# Altium schematic sheet RotaryEncoder.SchDoc
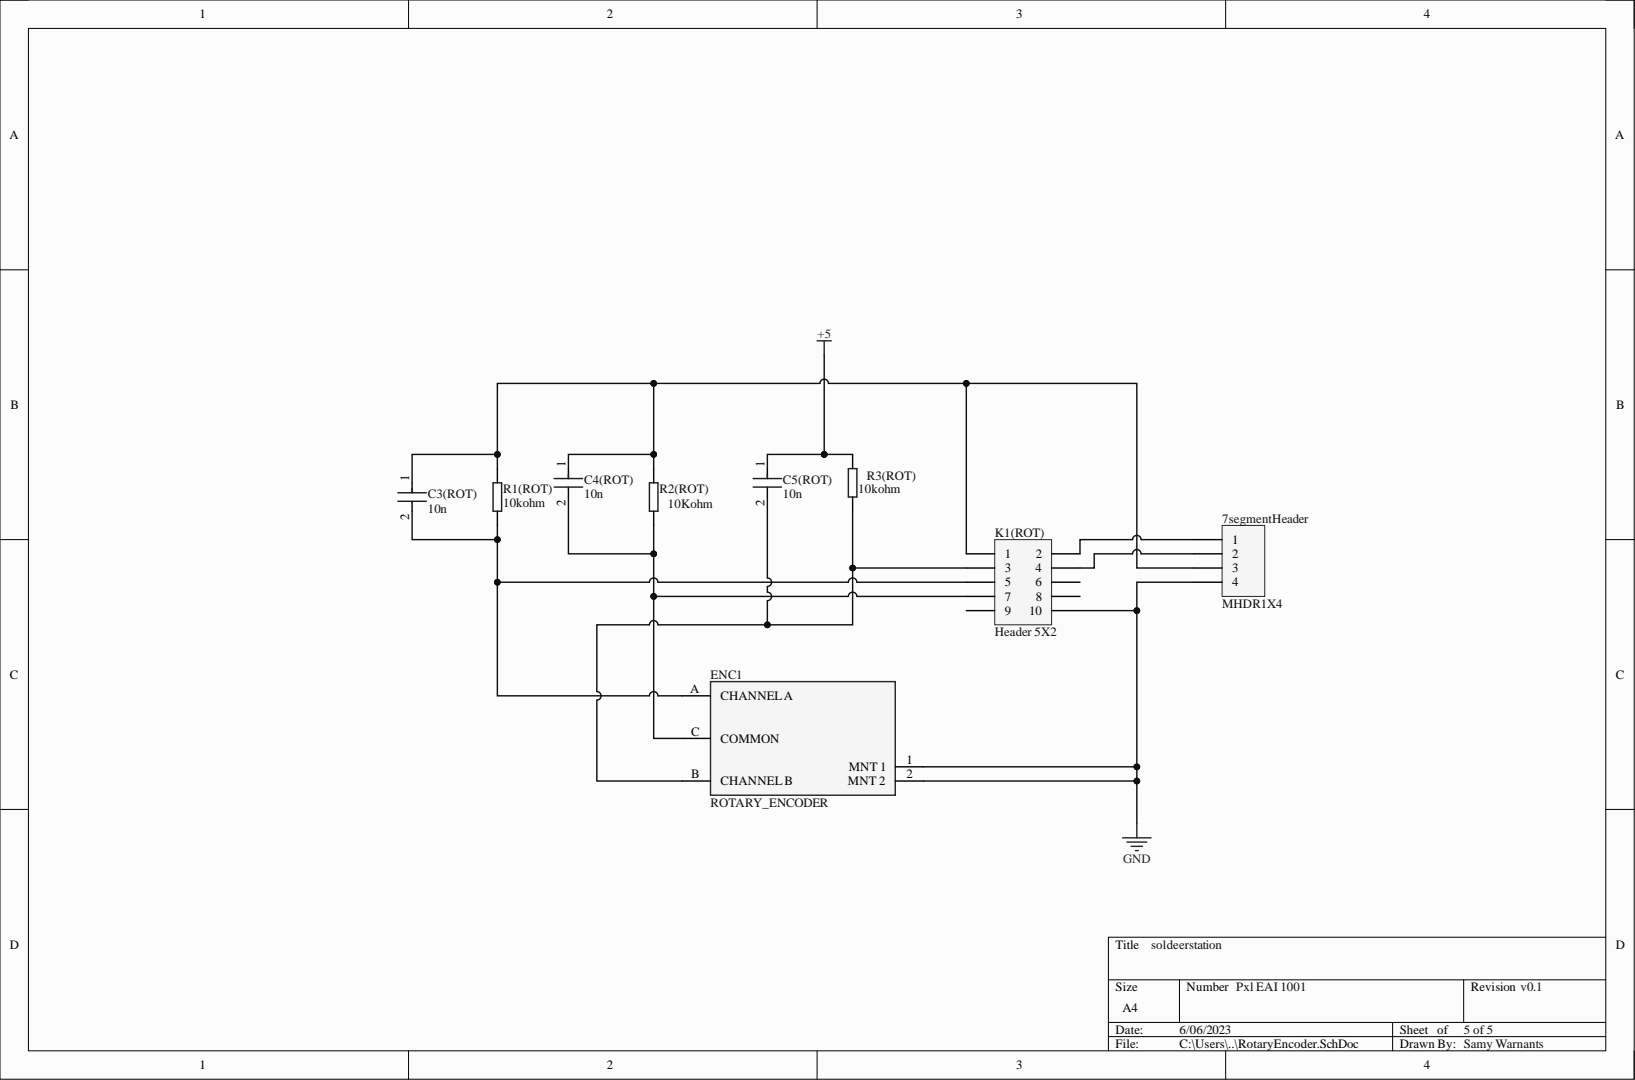
[diagram p0: RotaryEncoder.SchDoc]

## Parts
7segmentHeader  MHDR1X4  [MHDR1X4]
C3(ROT)  10n  [CC_0805_L]
C4(ROT)  10n  [CC_0805_L]
C5(ROT)  10n  [CC_0805_L]
ENC1  ROTARY_ENCODER  [PEC11R_4220F_S0012]
K1(ROT)  Header 5X2  [HDR2X5]
R1(ROT)  10kohm  [R_0805_M]
R2(ROT)  10Kohm  [R_0805_M]
R3(ROT)  10kohm  [R_0805_M]

## Nets
+5: C5(ROT).1 R3(ROT).2
GND: 7segmentHeader.4 ENC1.1(MNT_1) ENC1.2(MNT_2) K1(ROT).10
Net7segmentHeader_1: 7segmentHeader.1 K1(ROT).2
Net7segmentHeader_2: 7segmentHeader.2 K1(ROT).4
Net7segmentHeader_3: 7segmentHeader.3 C3(ROT).1 C4(ROT).1 K1(ROT).1 R1(ROT).2 R2(ROT).2
NetC3(ROT)_2: C3(ROT).2 ENC1.A(CHANNEL_A) K1(ROT).5 R1(ROT).1
NetC4(ROT)_2: C4(ROT).2 ENC1.C(COMMON) K1(ROT).7 R2(ROT).1
NetC5(ROT)_2: C5(ROT).2 ENC1.B(CHANNEL_B) K1(ROT).3 R3(ROT).1

Not connected: K1(ROT) 6 8 9

## Library data of the parts
7segmentHeader = MHDR1X4: Header, 4-Pin is used to power and control the external display port (Whadda 7 segment display: https://whadda.com/product/4-digit-display-with-driver-module-tm1637-driver-wpi425/; Component Kind: Standard; LatestRevisionDate: 17-Jul-2002; LatestRevisionNote: Re-released for DXP Platform.; Library Name: Miscellaneous Connectors.IntLib; Signal Integrity: Connector; Publisher: Altium Limited
C3(ROT) C4(ROT) C5(ROT) = Capacitor_Ceramic: Manufacturer: KEMET; Manufacturer Part Number: C0805C224K5RACTU; Capacitance: 0.22 μF; Temperature Characteristic: X7R; Temperature Coefficient: 30ppm/°C; Tolerance: 0.1; Value: 0.22 μF; Voltage Rating: 50 V; Automotive: No; Category: Cap; Component Kind: Standard; ComponentLink2Description: Datasheet; ComponentLink2URL: https://content.kemet.com/datasheets/KEM_C1003_C0G_SMD.pdf; Device Class L1: Passive Components; Device Class L2: Capacitors; Device Class L3: Ceramic Capacitors; Digikey Description: CAP CER 0.22UF 50V X7R 0805; Height: 0.88mm; Ipc Land Pattern Name: CAPC200125X78; Lead Free: Yes; Material: Ceramic; Mouser Description: CAP CER 0.22UF 50V X7R 0805; Octopart: https://octopart.com/c0805c224k5ractu-kemet-144670; Package: C0805C-DN; RoHS: TRUE; Temperature: -55°C to +125°C; Temperature Range High: +125°C; Temperature Range Low: -55°C; Library Name: Soldeerstation_Final (1).SchLib
ENC1 = ROTARY_ENCODER: Manufacturer: Bourns; Manufacturer Part Number: PEC11R-4015F-N0024; Conn Orientation: Vertical; Current Rating: 10mA; Detent: No; Encoder Type: Incremental; Number Of Circuits: 1; Pulses Per Rev: 24; Voltage Rating Dc: 5V; Automotive: No; Category: Enc; Component Kind: Standard; ComponentLink2Description: Datasheet; ComponentLink2URL: https://www.bourns.com/docs/product-datasheets/pec11r.pdf?sfvrsn=bb617cbf_7; Device Class L1: Electromechanical; Device Class L2: Switches; Device Class L3: Encoders; Digikey Description: ROTARY ENCODER MECHANICAL 24PPR; Height: 22.3mm; Lead Free: Yes; Mouser Description: Encoders 15mm SHAFT NO SWITCH; Octopart: https://octopart.com/pec11r-4015f-n0024-bourns-26648251; Package: 0; RoHS: TRUE; Temperature: -30°C to +70°C; Temperature Range High: +70°C; Temperature Range Low: -30°C; Library Name: Soldeerstation_Final (1).SchLib
K1(ROT) = Header 5X2: Header, 5-Pin, Dual row; Component Kind: Standard; LatestRevisionDate: 17-Jul-2002; LatestRevisionNote: Re-released for DXP Platform.; Library Name: Miscellaneous Connectors.IntLib; Signal Integrity: Connector; Publisher: Altium Limited
R1(ROT) R2(ROT) R3(ROT) = Resistor: Manufacturer: Vishay Dale; Part Number: CRCW08050000Z0EA; Component Kind: Standard; Library Name: Soldeerstation_Final (1).SchLib
